# Altium schematic sheet MCU.SchDoc
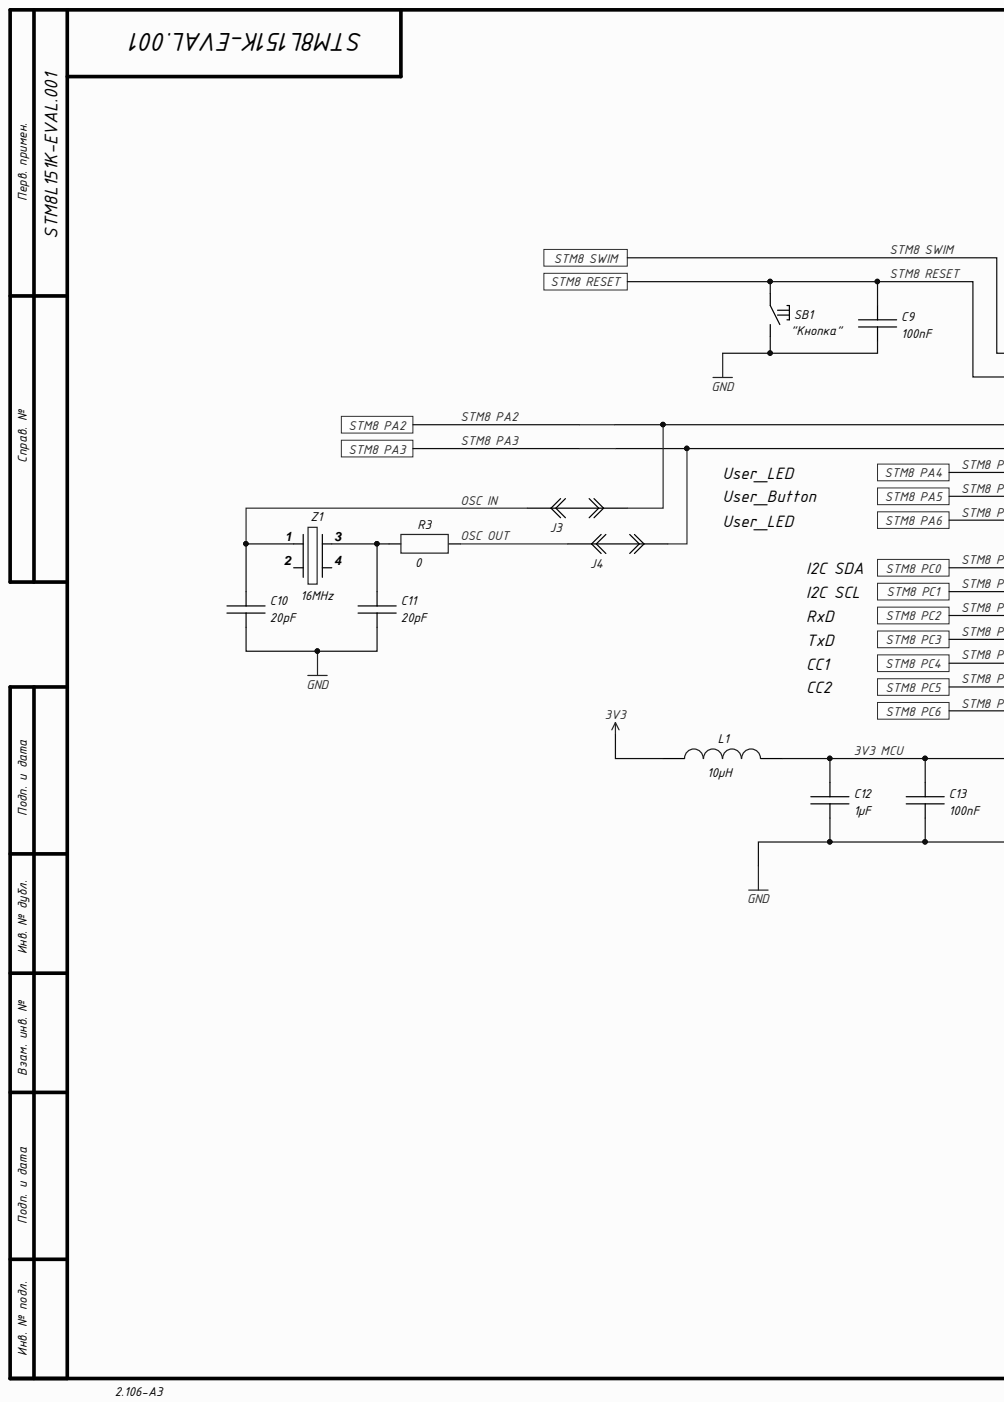
[diagram p0: MCU.SchDoc, left]
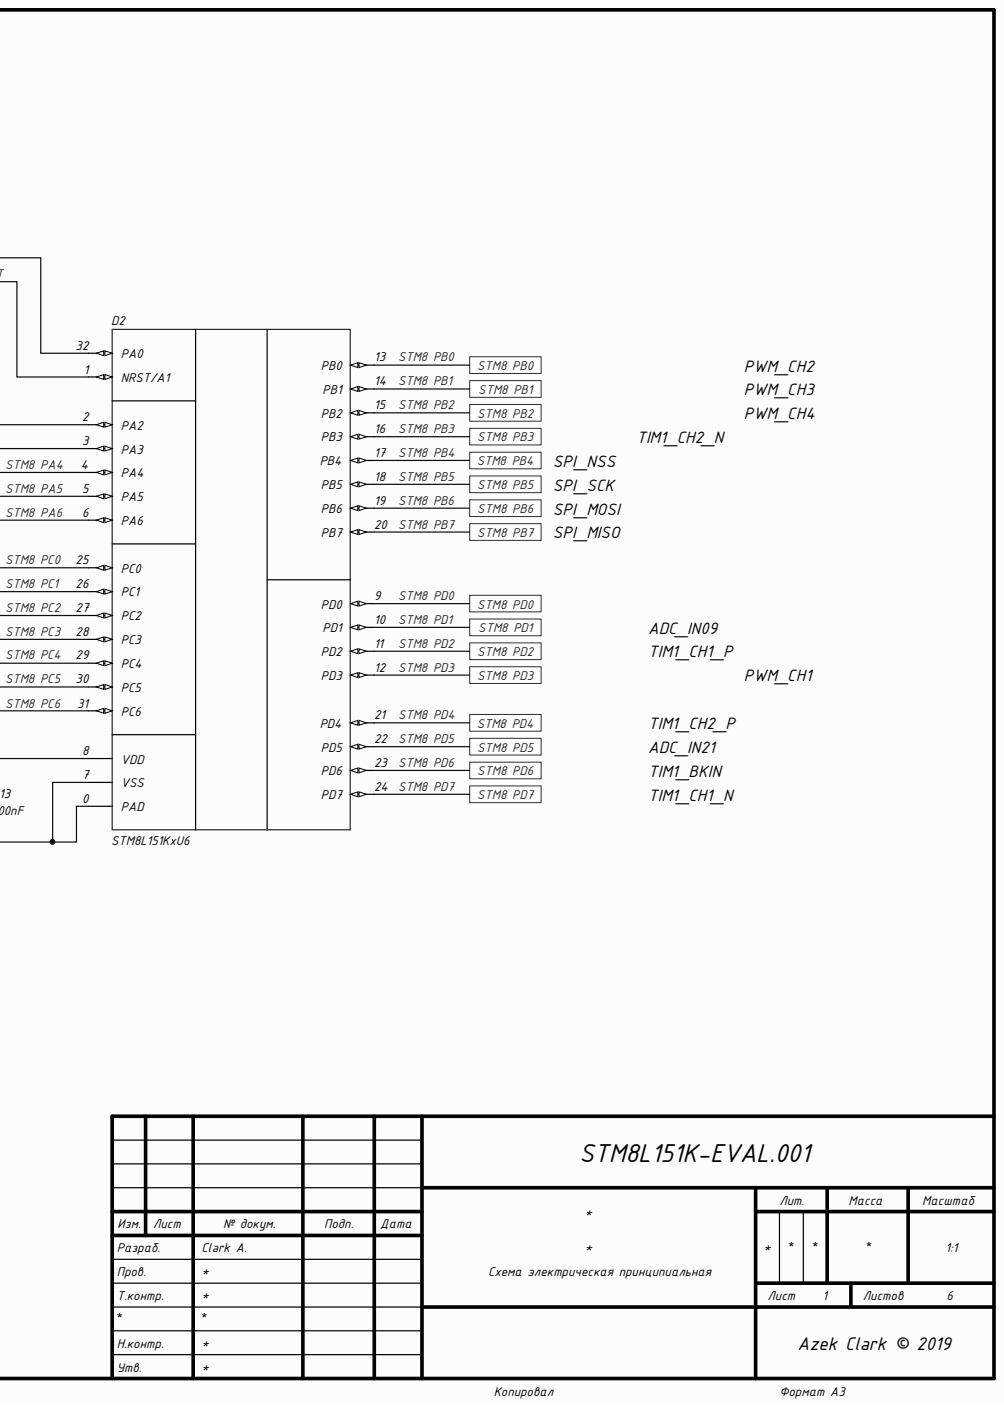
[diagram p1: MCU.SchDoc, right]

## Parts
C9  100nF  [CH0603C]
C10  20pF  [CH0603C]
C11  20pF  [CH0603C]
C12  1µF  [CH0603C]
C13  100nF  [CH0603C]
D2  STM8L151KxU6  [32-UFQFPN]
J3  Jumper  [CON:JUMPER SMT 2-way]  (value not drawn)
J4  Jumper  [CON:JUMPER SMT 2-way]  (value not drawn)
L1  10µH  [CH0603L]
R3  0  [CH0603R]
SB1  "Кнопка"  [BUT: 4X41.5 SMT]
Z1  16MHz  [OSC: 2520]

## Ports
STM8 PA2, STM8 PA3, STM8 PA4, STM8 PA5, STM8 PA6, STM8 PB0, STM8 PB1, STM8 PB2, STM8 PB3, STM8 PB4, STM8 PB5, STM8 PB6, STM8 PB7, STM8 PC0, STM8 PC1, STM8 PC2, STM8 PC3, STM8 PC4, STM8 PC5, STM8 PC6, STM8 PD0, STM8 PD1, STM8 PD2, STM8 PD3, STM8 PD4, STM8 PD5, STM8 PD6, STM8 PD7, STM8 RESET, STM8 SWIM

## Nets
3V3: L1.2
GND: C9.1 C10.1 C11.2 C12.1 C13.1 D2.0(PAD) D2.7(VSS) SB1.2
3V3 MCU: C12.2 C13.2 D2.8(VDD) L1.1
OSC IN: C10.2 J3.1 Z1.1
OSC OUT: J4.1 R3.2
STM8 PA2: D2.2(PA2) J3.2 ; port STM8 PA2
STM8 PA3: D2.3(PA3) J4.2 ; port STM8 PA3
STM8 PA4: D2.4(PA4) ; port STM8 PA4
STM8 PA5: D2.5(PA5) ; port STM8 PA5
STM8 PA6: D2.6(PA6) ; port STM8 PA6
STM8 PB0: D2.13(PB0) ; port STM8 PB0
STM8 PB1: D2.14(PB1) ; port STM8 PB1
STM8 PB2: D2.15(PB2) ; port STM8 PB2
STM8 PB3: D2.16(PB3) ; port STM8 PB3
STM8 PB4: D2.17(PB4) ; port STM8 PB4
STM8 PB5: D2.18(PB5) ; port STM8 PB5
STM8 PB6: D2.19(PB6) ; port STM8 PB6
STM8 PB7: D2.20(PB7) ; port STM8 PB7
STM8 PC0: D2.25(PC0) ; port STM8 PC0
STM8 PC1: D2.26(PC1) ; port STM8 PC1
STM8 PC2: D2.27(PC2) ; port STM8 PC2
STM8 PC3: D2.28(PC3) ; port STM8 PC3
STM8 PC4: D2.29(PC4) ; port STM8 PC4
STM8 PC5: D2.30(PC5) ; port STM8 PC5
STM8 PC6: D2.31(PC6) ; port STM8 PC6
STM8 PD0: D2.9(PD0) ; port STM8 PD0
STM8 PD1: D2.10(PD1) ; port STM8 PD1
STM8 PD2: D2.11(PD2) ; port STM8 PD2
STM8 PD3: D2.12(PD3) ; port STM8 PD3
STM8 PD4: D2.21(PD4) ; port STM8 PD4
STM8 PD5: D2.22(PD5) ; port STM8 PD5
STM8 PD6: D2.23(PD6) ; port STM8 PD6
STM8 PD7: D2.24(PD7) ; port STM8 PD7
STM8 RESET: C9.2 D2.1(NRST/A1) SB1.1 ; port STM8 RESET
STM8 SWIM: D2.32(PA0) ; port STM8 SWIM
NetC11_1: C11.1 R3.1 Z1.3

Not connected: Z1 2 4

## Library data of the parts
C9-C13 = Capacitor: Component Kind: Standard; Library Name: Discrete.IntLib
  C9 C13: Value: 100nF
  C10 C11: Value: 20pF
  C12: Value: 1µF
D2 = STM8L151KxU6: STM8; Manufacturer: ST Microelectronics; Model: STM8L151GxU6; Component Kind: Standard; ComponentKind: UFQFPN28; HelpURL: https://ru.mouser.com/datasheet/2/389/stm8l151c4-956418.pdf; Library Name: Digital.IntLib
J3 J4 = Jumper 2-way: 2-way jumper; Component Kind: Standard; Library Name: Connectors.IntLib
L1 = Inductor: Component Kind: Standard; Library Name: Discrete.IntLib; Value: 10µH
R3 = Resistor: Component Kind: Standard; Library Name: Discrete.IntLib; Value: 0
SB1 = Tactile switches: 6x6 mm; Component Kind: Standard; Library Name: Connectors.IntLib
Z1 = Generator: Component Kind: Standard; Library Name: Discrete.IntLib; Value: 16MHz
